# Altium schematic sheet Carrier_I.SchDoc(Carrier_I)
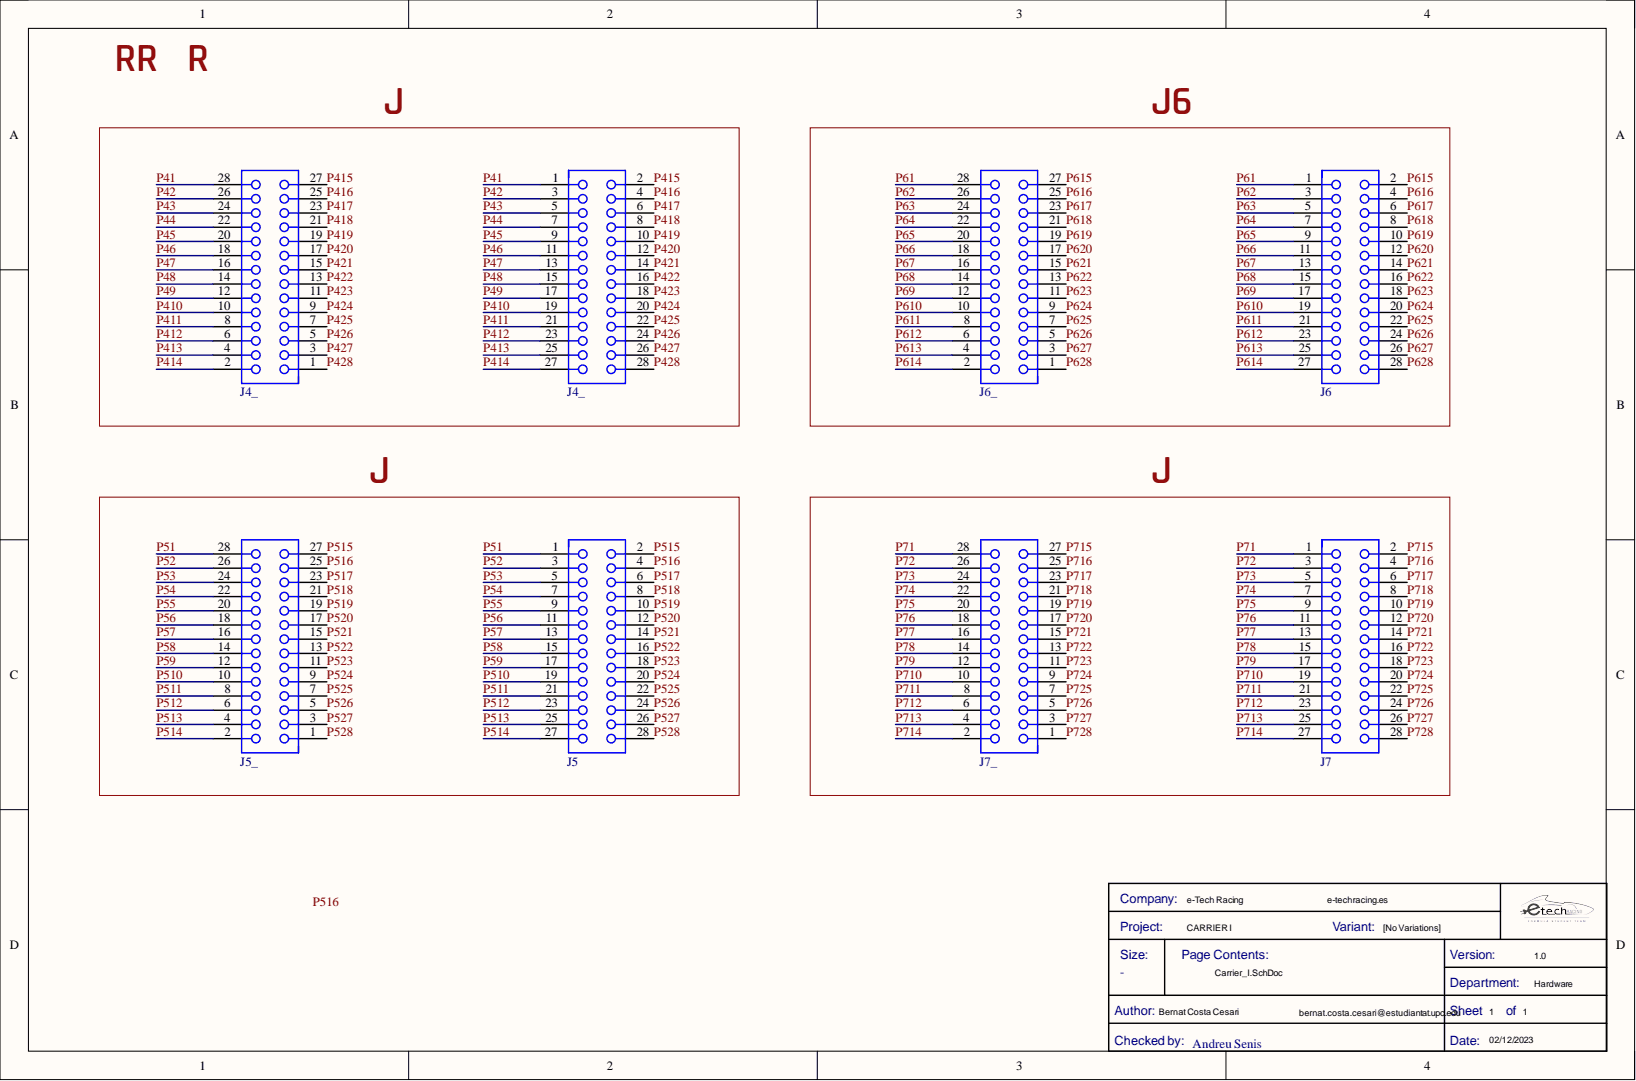
[diagram p0: Carrier_I.SchDoc]

## Parts
J4_  62332821021  [62302821021]  (value not drawn)
J4_  61002821821  [61002821821]  (value not drawn)
J5  62332821021  [62302821021]  (value not drawn)
J5_  61002821821  [61002821821]  (value not drawn)
J6  62332821021  [62302821021]  (value not drawn)
J6_  61002821821  [61002821821]  (value not drawn)
J7  62332821021  [62302821021]  (value not drawn)
J7_  61002821821  [61002821821]  (value not drawn)

## Nets
P41: J4_.1 J4_.28
P42: J4_.3 J4_.26
P43: J4_.5 J4_.24
P44: J4_.7 J4_.22
P45: J4_.9 J4_.20
P46: J4_.11 J4_.18
P47: J4_.13 J4_.16
P48: J4_.14 J4_.15
P49: J4_.12 J4_.17
P51: J5.1 J5_.28
P52: J5.3 J5_.26
P53: J5.5 J5_.24
P54: J5.7 J5_.22
P55: J5.9 J5_.20
P56: J5.11 J5_.18
P57: J5.13 J5_.16
P58: J5.15 J5_.14
P59: J5.17 J5_.12
P61: J6.1 J6_.28
P62: J6.3 J6_.26
P63: J6.5 J6_.24
P64: J6.7 J6_.22
P65: J6.9 J6_.20
P66: J6.11 J6_.18
P67: J6.13 J6_.16
P68: J6.15 J6_.14
P69: J6.17 J6_.12
P71: J7.1 J7_.28
P72: J7.3 J7_.26
P73: J7.5 J7_.24
P74: J7.7 J7_.22
P75: J7.9 J7_.20
P76: J7.11 J7_.18
P77: J7.13 J7_.16
P78: J7.15 J7_.14
P79: J7.17 J7_.12
P410: J4_.10 J4_.19
P411: J4_.8 J4_.21
P412: J4_.6 J4_.23
P413: J4_.4 J4_.25
P414: J4_.2 J4_.27
P415: J4_.2 J4_.27
P416: J4_.4 J4_.25
P417: J4_.6 J4_.23
P418: J4_.8 J4_.21
P419: J4_.10 J4_.19
P420: J4_.12 J4_.17
P421: J4_.14 J4_.15
P422: J4_.13 J4_.16
P423: J4_.11 J4_.18
P424: J4_.9 J4_.20
P425: J4_.7 J4_.22
P426: J4_.5 J4_.24
P427: J4_.3 J4_.26
P428: J4_.1 J4_.28
P510: J5.19 J5_.10
P511: J5.21 J5_.8
P512: J5.23 J5_.6
P513: J5.25 J5_.4
P514: J5.27 J5_.2
P515: J5.2 J5_.27
P516: J5.4 J5_.25
P517: J5.6 J5_.23
P518: J5.8 J5_.21
P519: J5.10 J5_.19
P520: J5.12 J5_.17
P521: J5.14 J5_.15
P522: J5.16 J5_.13
P523: J5.18 J5_.11
P524: J5.20 J5_.9
P525: J5.22 J5_.7
P526: J5.24 J5_.5
P527: J5.26 J5_.3
P528: J5.28 J5_.1
P610: J6.19 J6_.10
P611: J6.21 J6_.8
P612: J6.23 J6_.6
P613: J6.25 J6_.4
P614: J6.27 J6_.2
P615: J6.2 J6_.27
P616: J6.4 J6_.25
P617: J6.6 J6_.23
P618: J6.8 J6_.21
P619: J6.10 J6_.19
P620: J6.12 J6_.17
P621: J6.14 J6_.15
P622: J6.16 J6_.13
P623: J6.18 J6_.11
P624: J6.20 J6_.9
P625: J6.22 J6_.7
P626: J6.24 J6_.5
P627: J6.26 J6_.3
P628: J6.28 J6_.1
P710: J7.19 J7_.10
P711: J7.21 J7_.8
P712: J7.23 J7_.6
P713: J7.25 J7_.4
P714: J7.27 J7_.2
P715: J7.2 J7_.27
P716: J7.4 J7_.25
P717: J7.6 J7_.23
P718: J7.8 J7_.21
P719: J7.10 J7_.19
P720: J7.12 J7_.17
P721: J7.14 J7_.15
P722: J7.16 J7_.13
P723: J7.18 J7_.11
P724: J7.20 J7_.9
P725: J7.22 J7_.7
P726: J7.24 J7_.5
P727: J7.26 J7_.3
P728: J7.28 J7_.1

## Library data of the parts
J4_ J5-J7 = 62332821021: WR-PHD Socket Header, SMT, Vertical, pitch 1.27mm, 2 Row, 28P; Manufacturer: Wurth Elektronik; Contact Resistance: 20mΩ; Rated Current: 1A; Withstanding Voltage: 500V; Working Voltage: 250V; Category: Board-to-Board Connectors; Component Kind: Standard; ComponentLink1Description: Website Link; ComponentLink1URL: https://www.we-online.com/redexpert/article/62332821021?ad; ComponentLink2Description: Datasheet Link; ComponentLink2URL: https://www.we-online.com/redexpert/spec/62332821021?ad; Gender: Socket Header; Library Name: Electromechanical.SchLib; Manufacturer Part Number: 62332821021; Match Code: WR-PHD; Mount: Surface Mount; Number of Pins: 28; Number of Rows: 2; Operating Temperature Max: 105°C; Operating Temperature Min: -40°C; Orientation: Vertical; Packaging: Tape and Reel; Pitch: 1.27mm
J4_ J5_ J6_ J7_ = 61002821821: WR-PHD Socket Header, SMT, Vertical, pitch 2.54mm, 2 Row, 28P; Manufacturer: Wurth Elektronik; Contact Resistance: 20mΩ; Rated Current: 3A; Withstanding Voltage: 600V; Working Voltage: 250V; Category: Board-to-Board Connectors; Component Kind: Standard; ComponentLink1Description: Website Link; ComponentLink1URL: https://www.we-online.com/redexpert/article/61002821821?ad; ComponentLink2Description: Datasheet Link; ComponentLink2URL: https://www.we-online.com/redexpert/spec/61002821821?ad; Gender: Socket Header; Library Name: Electromechanical.SchLib; Manufacturer Part Number: 61002821821; Match Code: WR-PHD; Mount: Surface Mount; Number of Pins: 28; Number of Rows: 2; Operating Temperature Max: 105°C; Operating Temperature Min: -40°C; Orientation: Vertical; Packaging: Tube; Pitch: 2.54mm
